# Altium schematic sheet TopSheet.SchDoc
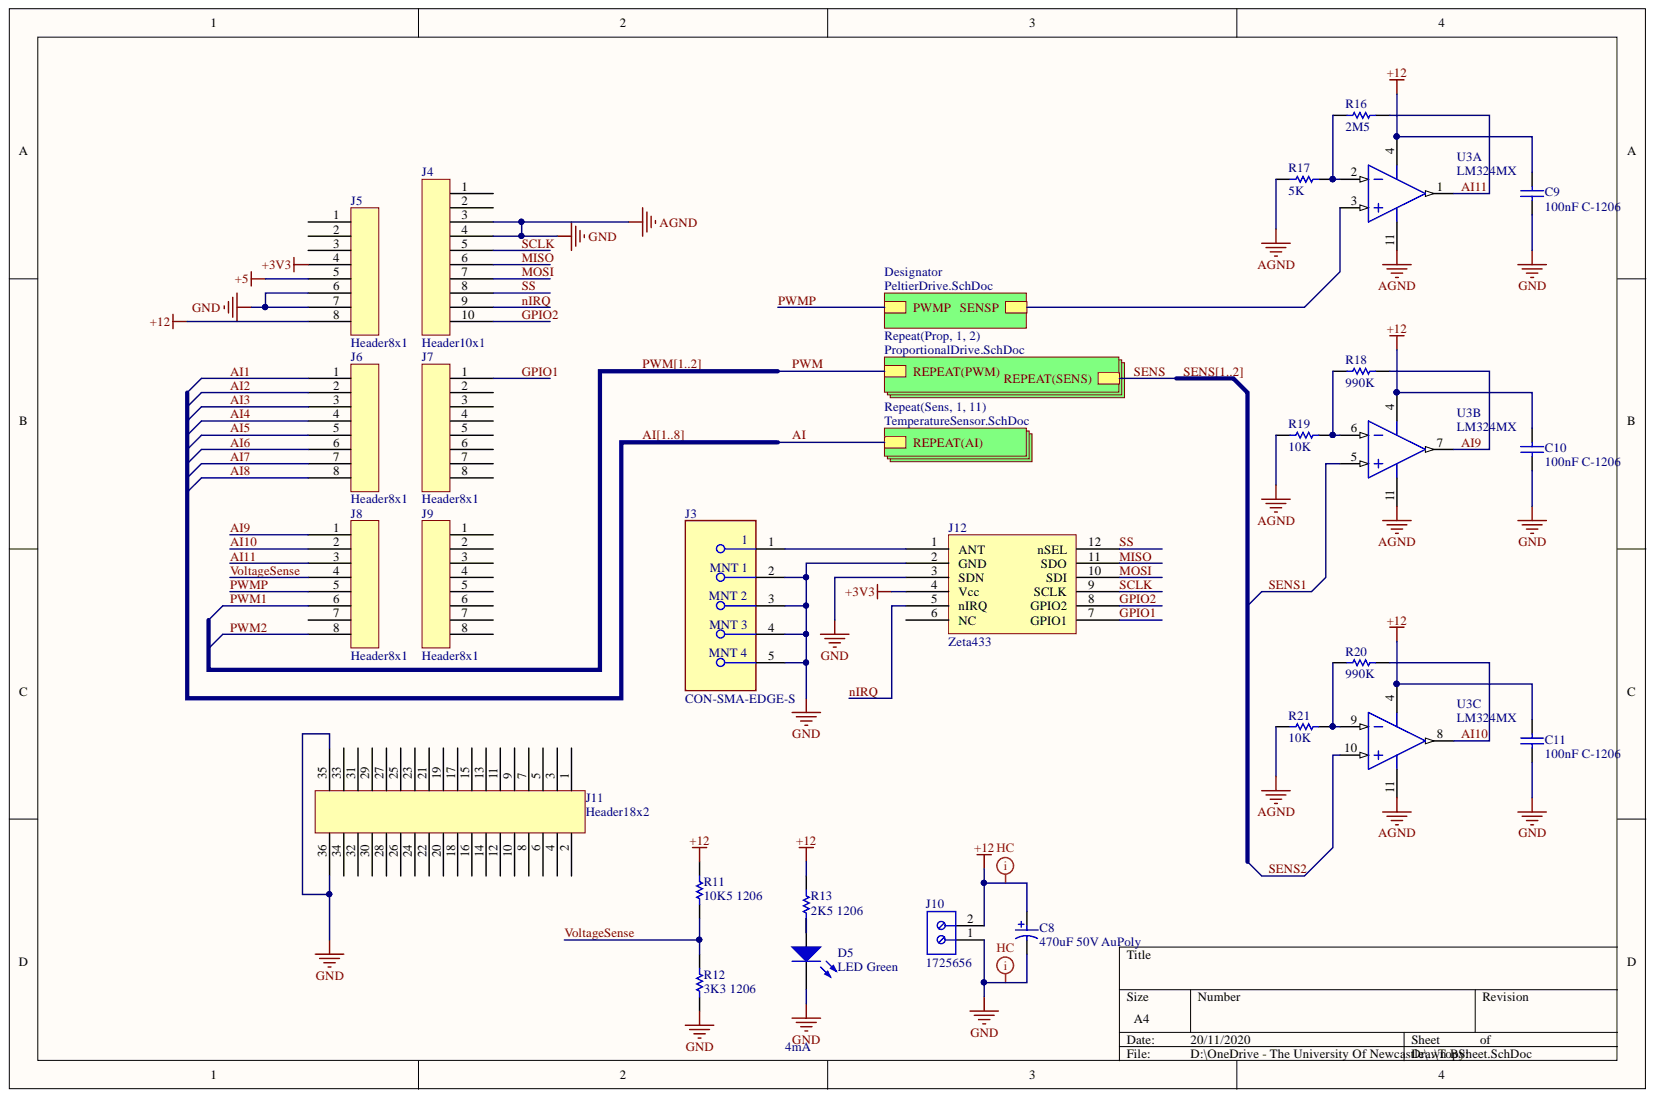
[diagram p0: TopSheet.SchDoc]

## Parts
C8  470uF 50V AuPoly  [CAPAE137130X163M]
C9  100nF C-1206  value 100nF  [C-1206]
C10  100nF C-1206  value 100nF  [C-1206]
C11  100nF C-1206  value 100nF  [C-1206]
D5  LED Green  [0603_A]
J3  CON-SMA-EDGE-S  [FP-CON-SMA-EDGE-S-MFG]
J4  Header10x1  [Header10x1]
J5  Header8x1  [Header8x1]
J6  Header8x1  [Header8x1]
J7  Header8x1  [Header8x1]
J8  Header8x1  [Header8x1]
J9  Header8x1  [Header8x1]
J10  1725656  [PHOE-1725656-2]
J11  Header18x2  [Header18x2]
J12  Zeta433  [Zeta433-SM]
R11  10K5 1206  [R-1206]
R12  3K3 1206  [R-1206]
R13  2K5 1206  [R-1206]
R16  2M5  [RESC0603(1608)_N]
R17  5K  [RESC0603(1608)_N]
R18  990K  [RESC0603(1608)_N]
R19  10K  [RESC0603(1608)_N]
R20  990K  [RESC0603(1608)_N]
R21  10K  [RESC0603(1608)_N]
U3  LM324MX  [D0014A_L]  (parts A,B,C)

## Nets
+3V3: J5.4 J12.4(Vcc)
+5: J5.5
+12: C8.1 C9.2 C10.2 C11.2 J5.8 J10.2 R11.2 R13.2 U3.4
AGND: C8.2 C9.1 C10.1 C11.1 D5.1 J3.2 J3.3 J3.4 J3.5 J4.3 J4.4 J5.6 J5.7 J10.1 J11.35 J11.36 J12.2(GND) J12.3(SDN) R12.1 R17.1 R19.1 R21.1 U3.11
AI1: J6.1  [also labelled AI]
AI2: J6.2  [also labelled AI]
AI3: J6.3  [also labelled AI]
AI4: J6.4  [also labelled AI]
AI5: J6.5  [also labelled AI]
AI6: J6.6  [also labelled AI]
AI7: J6.7  [also labelled AI]
AI8: J6.8  [also labelled AI]
AI9: J8.1 R18.2 U3.7  [also labelled AI]
AI10: J8.2 R20.2 U3.8  [also labelled AI]
AI11: J8.3 R16.2 U3.1  [also labelled AI]
GPIO1: J7.1 J12.7(GPIO1)
GPIO2: J4.10 J12.8(GPIO2)
MISO: J4.6 J12.11(SDO)
MOSI: J4.7 J12.10(SDI)
nIRQ: J4.9 J12.5(nIRQ)
PWM1: J8.6  [also labelled PWM]
PWM2: J8.8  [also labelled PWM]
PWMP: J8.5
SCLK: J4.5 J12.9(SCLK)
SENS1: U3.5  [also labelled SENS]
SENS2: U3.10  [also labelled SENS]
SS: J4.8 J12.12(nSEL)
VoltageSense: J8.4 R11.1 R12.2
NetD5_2: D5.2 R13.1
NetJ3_1: J3.1 J12.1(ANT)
NetJ4_1: J4.1
NetJ4_2: J4.2
NetJ5_1: J5.1
NetJ5_2: J5.2
NetJ5_3: J5.3
NetJ7_2: J7.2
NetJ7_3: J7.3
NetJ7_4: J7.4
NetJ7_5: J7.5
NetJ7_6: J7.6
NetJ7_7: J7.7
NetJ7_8: J7.8
NetJ8_7: J8.7
NetJ9_1: J9.1
NetJ9_2: J9.2
NetJ9_3: J9.3
NetJ9_4: J9.4
NetJ9_5: J9.5
NetJ9_6: J9.6
NetJ9_7: J9.7
NetJ9_8: J9.8
NetJ11_1: J11.1
NetJ11_2: J11.2
NetJ11_3: J11.3
NetJ11_4: J11.4
NetJ11_5: J11.5
NetJ11_6: J11.6
NetJ11_7: J11.7
NetJ11_8: J11.8
NetJ11_9: J11.9
NetJ11_10: J11.10
NetJ11_11: J11.11
NetJ11_12: J11.12
NetJ11_13: J11.13
NetJ11_14: J11.14
NetJ11_15: J11.15
NetJ11_16: J11.16
NetJ11_17: J11.17
NetJ11_18: J11.18
NetJ11_19: J11.19
NetJ11_20: J11.20
NetJ11_21: J11.21
NetJ11_22: J11.22
NetJ11_23: J11.23
NetJ11_24: J11.24
NetJ11_25: J11.25
NetJ11_26: J11.26
NetJ11_27: J11.27
NetJ11_28: J11.28
NetJ11_29: J11.29
NetJ11_30: J11.30
NetJ11_31: J11.31
NetJ11_32: J11.32
NetJ11_33: J11.33
NetJ11_34: J11.34
NetJ12_6: J12.6(NC)
NetR16_1: R16.1 R17.2 U3.2
NetR18_1: R18.1 R19.2 U3.6
NetR20_1: R20.1 R21.2 U3.9
NetU3_3: U3.3

## Library data of the parts
C8 = C-Poly: Manufacturer: AVX; Capacitance: 22000000pF; Case Style: Molded; DCL: 5.5uA; DELETED: Compliant; DF: 6%; ESR: 0.2ohm; Insulation: NotRequired; Lead Spacing (nom): NotRequiredmm; Polarity: Polar; Product Depth (mm): 4.3mm; Product Height (mm): 2.9mm; Product Length (mm): 7.3mm; Rad Hardened: No; Tolerance (+ or -): 10%; Voltage: 25VDC; Case Code: D; Component Kind: Standard; Construction: SMTChip; Datasheet URL: https://datasheet.ciiva.com/11793/0900766b80066cb4-11793194.pdf; Datasheet Version: 02/2004; Failure Rate: NotRequired; Length W/Weld: NotRequiredmm; Length W/Weld (max): NotRequiredmm; Library Name: Schlib1.SchLib; Manufacturer URL: http://www.avx.com/; Mounting Style: SurfaceMount; Mounting Technology: SurfaceMount; Operating Temp Range: -55Cto125C; Package / Case: 7343-31; Package Description: 2-Pin Surface Mount Device, Body 7.3 x 4.35 mm; Package Reference: 7343-31; Packaging: TapeandReel; Product Diameter (mm): NotRequiredmm; Seal: NotRequired; Seated Plane Height: NotRequiredmm; Wire Form: NotRequired
C9-C11 = C-1206: Ceramic 50V 1206; Case-EIA: 1206; Case-Metric: 3216; Tolerance: Â±20%; Component Kind: Standard; Library Name: Schlib1.SchLib; Max Thickness: 1 mm; Rated Voltage: 50 V; Technology: SMT
D5 = CMP-1426-00002-1: SMD mono-color Chip LED, WL-SMCW, Green; Manufacturer: Wuerth Elektronik; PartNumber: 150060GS75000; Color: Green; Component Kind: Standard; ComponentLink1Description: Manufacturer URL; ComponentLink1URL: http://www.we-online.com; ComponentLink2Description: Datasheet; ComponentLink2URL: http://katalog.we-online.com/led/datasheet/150060GS75000.pdf; Library Name: Altium Content Vault; PackageReference: 0603_A
J3 = CMP-204357-000001-1: CONN SMA JACK STR EDGE MNT; Manufacturer: RF Solutions Ltd.; Conn Orientation: Right Angle; Frequency: 18GHz; Impedance: 50Ω; Is Female: yes; Number Of Contacts: 1; Shielding: true; Automotive: No; Category: Conn; Component Kind: Standard; ComponentLink2Description: Datasheet; ComponentLink2URL: https://www.rfsolutions.co.uk/downloads/1498648656DS-CON-EDGE-SMA-4.pdf; Contact Material: Gold; Device Class L1: Connectors; Device Class L2: RF / Coaxial Connectors; Device Class L3: unset; Digikey Description: CONN SMA JACK STR EDGE MNT; Footprint Url: https://forum.upverter.com/uploads/default/original/3X/b/e/be75b907af57498775929d6d9099689c472e0307.pdf; Height: 6.35mm; Lead Free: Yes; Library Name: Altium Content Vault; Manufacturer Part Number: CON-SMA-EDGE-S; Octopart: https://octopart.com/con-sma-edge-s-rf+solutions-70967450; Package: CONN_JACK; RoHS: TRUE
J10 = CMP-2000-05299-1: Male Header, Pitch 2.54 mm, 1 x 2 Position, Height 9 mm, Tail Length 3.5 mm; Manufacturer: Phoenix Contact; Content: 250pc(s); Industrial packaging: Yes; Number of contacts: 2; Pitch: 2.54mm; Colour: Green; Component Kind: Standard; Datasheet URL: http://datasheet.octopart.com/1725656-Phoenix-Contact-datasheet-5302866.pdf; Datasheet Version: Apr-08; Library Name: Altium Content Vault; Manufacturer part No.: 1725656; Manufacturer URL: http://www.phoenixcontact.com/; Type: MPT0,5/2-2,54
R11-R13 = R-1206: 0.25W 1206; Case-EIA: 0603; Case-Metric: 1608; Power: 0.1 W; Tolerance: 1 %; Value: 10000.00 Ohm; Component Kind: Standard; Library Name: Schlib1.SchLib; Technology: SMT
R16-R21 = CMP-1012-00623-1: 10K 0.1W 1% 0603 (1608 Metric)  SMD; Case-EIA: 0603; Case-Metric: 1608; Value: 10000.00 Ohm; Component Kind: Standard; Library Name: Altium Content Vault; Power: 0.1 W; Technology: SMT; Tolerance: 1 %
U3 = CMP-0055-00741-3: Low Power Quad Operational Amplifier, 14-pin Narrow SOIC; Manufacturer: Texas Instruments; CompType: Amplifiers; PackageTopMarking: NSUZXYTTE#*LM324M; PowerWise: N; Code_IPC: SOIC127P600-14; Code_JEDEC: MS-012AB; Component Kind: Standard; ComponentLink1Description: Datasheet URL; ComponentLink1URL: http://www.ti.com/general/docs/lit/getliterature.tsp?genericPartNumber=LM324-N&fileType=pdf; Library Name: Altium Content Vault; PackageDescription: Molded Package S.O., .150in Wide, 14 Lead; Package Reference: D0014A; PinCount: 14; RoHS: N
J4 = Header10x1: Component Kind: Standard; Library Name: Schlib1.SchLib
J5-J9 = Header8x1: Component Kind: Standard; Library Name: Schlib1.SchLib
J11 = Header18x2: Component Kind: Standard; Library Name: Schlib1.SchLib
J12 = Zeta433: Component Kind: Standard; Library Name: Schlib1.SchLib
